# Altium schematic sheet Quadruped.SchDoc(Quadruped)
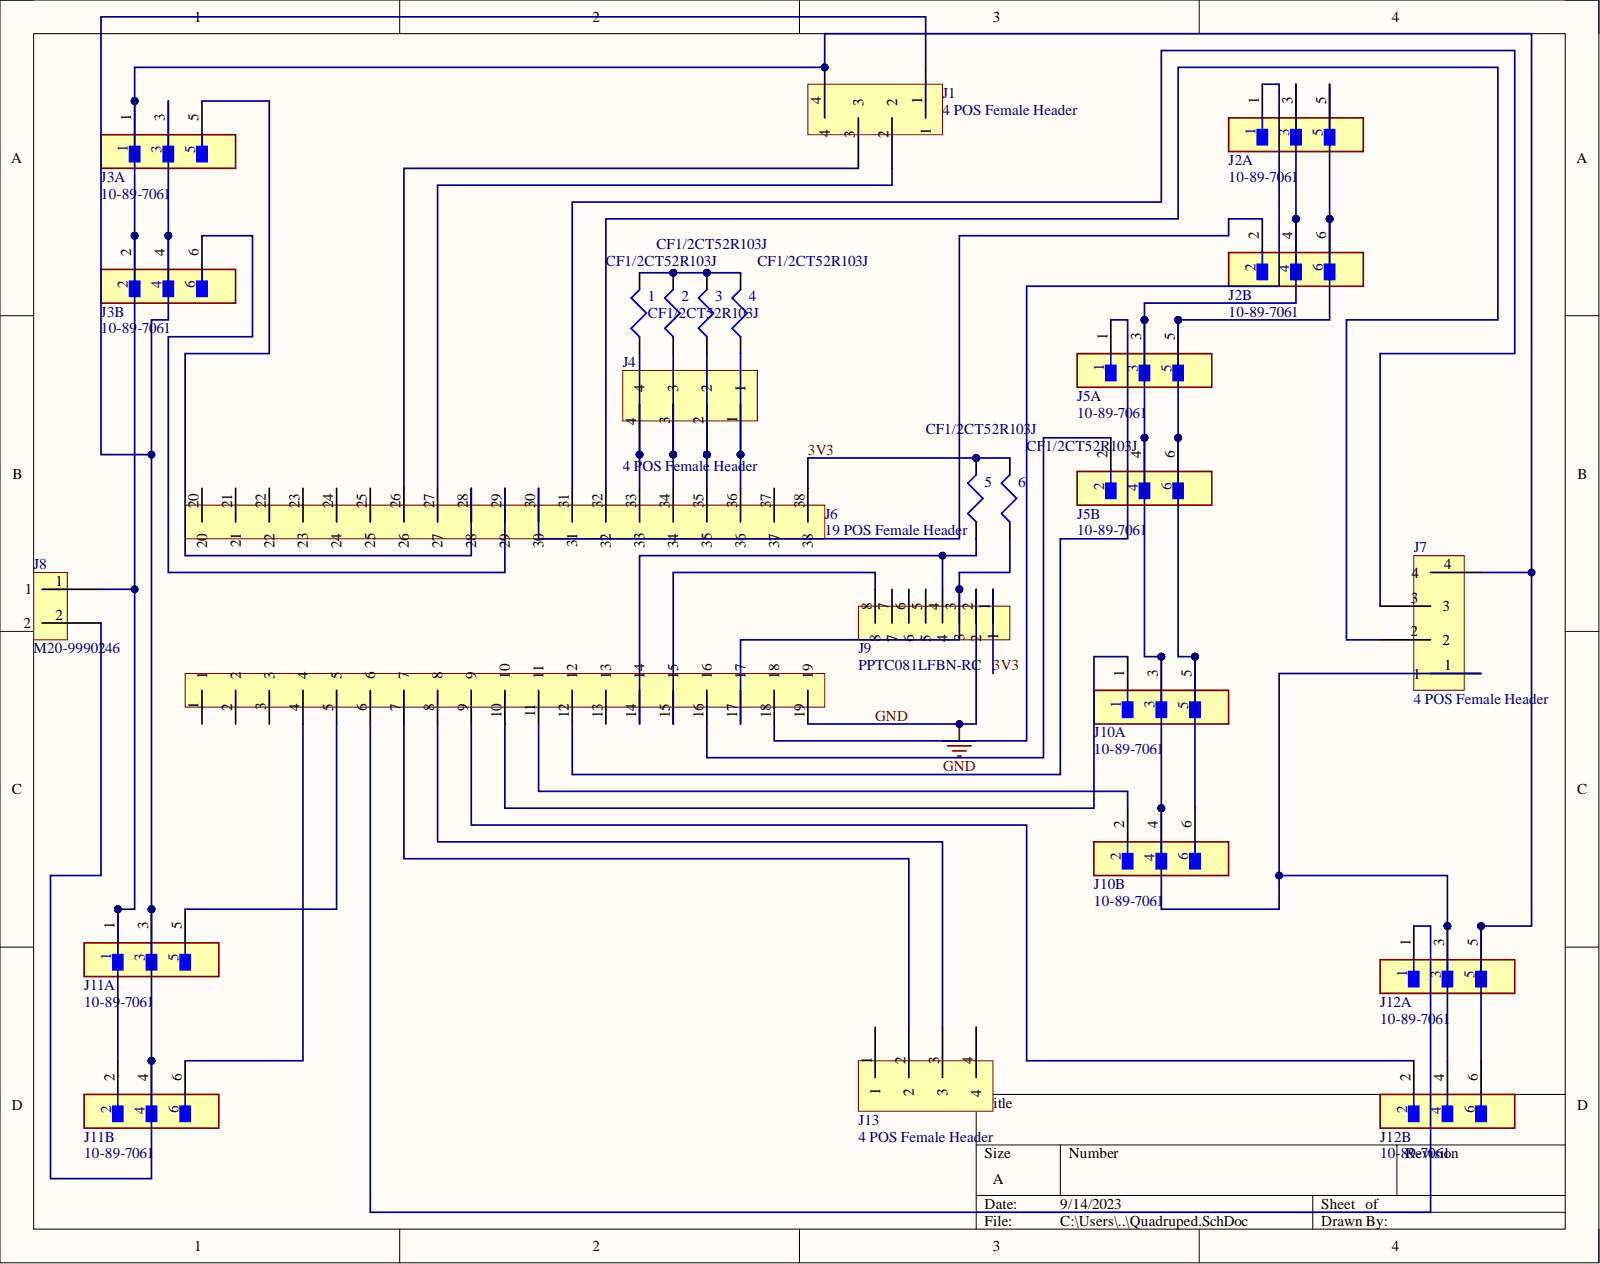
[diagram p0: Quadruped.SchDoc]

## Parts
1  CF1/2CT52R103J  [10K 1/2W]
2  CF1/2CT52R103J  [10K 1/2W]
3  CF1/2CT52R103J  [10K 1/2W]
4  CF1/2CT52R103J  [10K 1/2W]
5  CF1/2CT52R103J  [10K 1/2W]
6  CF1/2CT52R103J  [10K 1/2W]
J1  4 POS Female Header  [SULLINS_PPTC041LFBN-RC]
J2  10-89-7061  [3x2_MALE_HEADER]  (parts A,B)
J3  10-89-7061  [3x2_MALE_HEADER]  (parts A,B)
J4  4 POS Female Header  [SULLINS_PPTC041LFBN-RC]
J5  10-89-7061  [3x2_MALE_HEADER]  (parts A,B)
J6  19 POS Female Header  [ESP_32]
J7  4 POS Female Header  [SULLINS_PPTC041LFBN-RC]
J8  M20-9990246  [2x1_MALE_HEADER]
J9  PPTC081LFBN-RC  [8POS_FEMALE_HEADER]
J10  10-89-7061  [3x2_MALE_HEADER]  (parts A,B)
J11  10-89-7061  [3x2_MALE_HEADER]  (parts A,B)
J12  10-89-7061  [3x2_MALE_HEADER]  (parts A,B)
J13  4 POS Female Header  [SULLINS_PPTC041LFBN-RC]

## Nets
3V3: 5.2 6.2 J6.38 J9.1
GND: J6.19 J9.2
Net1_1: 1.1 J4.4 J6.33
Net1_2: 1.2 2.2 3.2 4.2
Net2_1: 2.1 J4.3 J6.34
Net3_1: 3.1 J4.2 J6.35
Net4_1: 4.1 J4.1 J6.36
Net5_1: 5.1 J6.14 J9.4
Net6_1: 6.1 J6.17 J9.3
NetJ1_1: J1.1 J3.3 J3.4 J8.2 J11.3 J11.4
NetJ1_2: J1.2 J6.27
NetJ1_3: J1.3 J6.26
NetJ1_4: J1.4 J3.1 J3.2 J7.4 J8.1 J11.1 J11.2 J12.5 J12.6
NetJ2_1: J2.1 J6.18
NetJ2_2: J2.2 J6.30
NetJ2_3: J2.3 J2.4 J5.3 J5.4 J7.1 J10.3 J10.4 J12.3 J12.4
NetJ2_5: J2.5 J2.6 J5.5 J5.6 J10.5 J10.6
NetJ3_5: J3.5 J6.28
NetJ3_6: J3.6 J6.29
NetJ5_1: J5.1 J6.12
NetJ5_2: J5.2 J6.16
NetJ6_4: J6.4 J11.6
NetJ6_5: J6.5 J11.5
NetJ6_6: J6.6 J12.1
NetJ6_7: J6.7 J13.2
NetJ6_8: J6.8 J13.3
NetJ6_9: J6.9 J12.2
NetJ6_10: J6.10 J10.1
NetJ6_11: J6.11 J10.2
NetJ6_15: J6.15 J9.8
NetJ6_31: J6.31 J7.3
NetJ6_32: J6.32 J7.2

Not connected: J6 1 2 3 13 20 21 22 23 24 25 37; J9 5 6 7; J13 1 4

## Library data of the parts
1-6 = CF1/2CT52R103J: Res, 10K, 0.5W, Axial, Carbon Film Rohs Compliant: Yes |Koa CF1/2CT52R103J; Manufacturer: KOA Speer; Power Rating: 500mW; Temperature Coefficient: 350ppm/°C; Tolerance: 5%; Value: 10kR; Voltage Rating: 400V; Case/Package: Conformal; Category: Through-Hole Resistors; Component Kind: Standard; ComponentLink1Description: https://datasheet.ciiva.com/5478/cf-5478522.pdf?src-supplier=Mouser; ComponentLink1URL: https://datasheet.ciiva.com/5478/cf-5478522.pdf?src-supplier=Mouser; ComponentLink2Description: https://datasheet.octopart.com/CF1-2CT52R103J-KOA-Speer-datasheet-149521760.pdf?src-supplier=Farnell; ComponentLink2URL: https://datasheet.octopart.com/CF1-2CT52R103J-KOA-Speer-datasheet-149521760.pdf?src-supplier=Farnell; ComponentLink3Description: https://datasheet.octopart.com/CF1/2CT52R103J-KOA-Speer-datasheet-160006.pdf?src-supplier=Mouser; ComponentLink3URL: https://datasheet.octopart.com/CF1/2CT52R103J-KOA-Speer-datasheet-160006.pdf?src-supplier=Mouser; Construction: Cylindrical; Length: 8.5mm; Manufacturer Part Number: CF1/2CT52R103J; Max Operating Temperature: 155°C; Military Standard: Not; Min Operating Temperature: -55°C; Mounting Technology: Through Hole; Package Quantity: 4000; Packaging: Tape and Reel; Pricing: 1=0.12, 10=0.104, 25=0.072, 50=0.0558, 100=0.0399, 250=0.03112, 500=0.02474, 1000=0.01755 (USD); RoHS Compliant: Yes; Series: CF; Stock: 26977; Supplier: Digi-Key; Supplier Part Number: 2019-CF1/2CT52R103JCT-ND; Termination: Axial; Library Name: Try1.SchLib
J1 J7 = PPPC041LFBN-RC_OPPOSITE: CONN HDR 4POS 0.1 GOLD PCB; Manufacturer: Sullins; Category: Connectors; Component Kind: Standard; ComponentLink1Description: https://media.digikey.com/pdf/Data%20Sheets/Sullins%20PDFs/Female_Headers.100_DS.pdf?src-supplier=Digi-Key; ComponentLink1URL: https://media.digikey.com/pdf/Data%20Sheets/Sullins%20PDFs/Female_Headers.100_DS.pdf?src-supplier=Digi-Key; ComponentLink2Description: http://www.sullinscorp.com/catalogs/78_Page80-81_HEADER_.100_2.54mm_FEMALE.pdf?src-supplier=Future+Electronics; ComponentLink2URL: http://www.sullinscorp.com/catalogs/78_Page80-81_HEADER_.100_2.54mm_FEMALE.pdf?src-supplier=Future+Electronics; ComponentLink3Description: https://datasheet.octopart.com/PPPC041LFBN-RC-Sullins-datasheet-41308905.pdf?src-supplier=Future+Electronics; ComponentLink3URL: https://datasheet.octopart.com/PPPC041LFBN-RC-Sullins-datasheet-41308905.pdf?src-supplier=Future+Electronics; Manufacturer Part Number: PPPC041LFBN-RC; Pricing: 1=0.47, 10=0.411, 100=0.3391, 500=0.29484, 1000=0.24324, 5000=0.21376, 10000=0.2106 (USD); RoHS: Yes; Stock: 27520; Supplier: Digi-Key; Supplier Part Number: S7037-ND; Library Name: Try1.SchLib
J2 J3 J5 J10-J12 = 2654a11fb13586ecb194516b1c997bc: CONN HEADER VERT 6POS 2.54MM; Connector Type: Male Pin; Contact Resistance: 15mR; Current Rating: 3A; Gender: Male; Glow Wire Compliant: Non-compliant; Number of Circuits: 6; Row Spacing: 0.1inch; Voltage Rating: 250V; Color: Black; Component Kind: Standard; Contact Gender: Male; Contact Material: Brass; Contact Mating Length: 0.24inch; Contact Plating: Tin; Contact Type: Male Pin; Depth: 5.08mm; ELV: Compliant; Flammability Rating: UL94 V-0; Halogen Free: Low Halogen; Housing Color: Black; Housing Material: Thermoplastic; Lead Free: Lead Free; Material: Copper Alloy; Mount: Through Hole; Number of Contacts: 6; Number of Positions: 6; Number of Rows: 2; Orientation: Straight; Package Quantity: 1; Packaging: Bulk; Pitch: 2.54mm; Radiation Hardening: No; RoHS Compliant: Yes; Series: C-Grid® 70280; Termination: Solder; Voltage Rating (DC): 250V; Library Name: Try1.SchLib
J4 J13 = PPPC041LFBN-RC: CONN HDR 4POS 0.1 GOLD PCB; Manufacturer: Sullins; Category: Connectors; Component Kind: Standard; ComponentLink1Description: https://media.digikey.com/pdf/Data%20Sheets/Sullins%20PDFs/Female_Headers.100_DS.pdf?src-supplier=Digi-Key; ComponentLink1URL: https://media.digikey.com/pdf/Data%20Sheets/Sullins%20PDFs/Female_Headers.100_DS.pdf?src-supplier=Digi-Key; ComponentLink2Description: http://www.sullinscorp.com/catalogs/78_Page80-81_HEADER_.100_2.54mm_FEMALE.pdf?src-supplier=Future+Electronics; ComponentLink2URL: http://www.sullinscorp.com/catalogs/78_Page80-81_HEADER_.100_2.54mm_FEMALE.pdf?src-supplier=Future+Electronics; ComponentLink3Description: https://datasheet.octopart.com/PPPC041LFBN-RC-Sullins-datasheet-41308905.pdf?src-supplier=Future+Electronics; ComponentLink3URL: https://datasheet.octopart.com/PPPC041LFBN-RC-Sullins-datasheet-41308905.pdf?src-supplier=Future+Electronics; Manufacturer Part Number: PPPC041LFBN-RC; Pricing: 1=0.47, 10=0.411, 100=0.3391, 500=0.29484, 1000=0.24324, 5000=0.21376, 10000=0.2106 (USD); RoHS: Yes; Stock: 27520; Supplier: Digi-Key; Supplier Part Number: S7037-ND; Library Name: Try1.SchLib
J6 = PPTC191LFBN-RC: CONN HDR 19POS 0.1 TIN PCB; Manufacturer: Sullins; Number of Positions: 19; Voltage Rating: 250V; Category: Connectors; Component Kind: Standard; ComponentLink1Description: https://s3.amazonaws.com/catalogspreads-pdf/PAGE114-115%20.100%20FEMALE%20HDR.pdf?src-supplier=Digi-Key; ComponentLink1URL: https://s3.amazonaws.com/catalogspreads-pdf/PAGE114-115%20.100%20FEMALE%20HDR.pdf?src-supplier=Digi-Key; Connector Type: Header; Contact Finish - Mating: TIN; Contact Material: Bronze; Contact Shape: Square; Contact Type: Female Socket; Fastening Type: Pull; Flammability Rating: UL94 V-0; Height: 8.5mm; Housing Material: Plastic; Insulation Color: Black; Insulation Material: Polyester; Lead Free: Lead Free; Length: 48.8mm; Manufacturer Part Number: PPTC191LFBN-RC; Max Operating Temperature: 105°C; Min Operating Temperature: -40°C; Mounting Technology: Through Hole; Number of Rows: 1; Packaging: Tray; Pricing: 1=1.18, 10=0.997, 80=0.89488, 440=0.73218, 1000=0.65083, 5000=0.5811 (USD); RoHS: Yes; RoHS Compliant: Yes; Series: -; Stock: 11638; Supplier: Digi-Key; Supplier Part Number: S7017-ND; Termination: Solder; Width: 2.5mm; Library Name: Try1.SchLib
J8 = M20-9990246: 2.54mm (0.1") Pitch SIL Vertical PC Tail Pin Header, 6.1mm mating pin height, tin, 2 contacts; Manufacturer: Harwin; Category: Headers and Wire Housings; Component Kind: Standard; ComponentLink1Description: https://datasheet.ciiva.com/8046/315376-8046930.pdf?src-supplier=Element14; ComponentLink1URL: https://datasheet.ciiva.com/8046/315376-8046930.pdf?src-supplier=Element14; ComponentLink2Description: https://datasheet.ciiva.com/11745/0900766b81034e61-11745755.pdf?src-supplier=Rs; ComponentLink2URL: https://datasheet.ciiva.com/11745/0900766b81034e61-11745755.pdf?src-supplier=Rs; ComponentLink3Description: https://datasheet.ciiva.com/22660/1830818-22660571.pdf?src-supplier=Element14; ComponentLink3URL: https://datasheet.ciiva.com/22660/1830818-22660571.pdf?src-supplier=Element14; ComponentLink4Description: https://datasheet.ciiva.com/6833/m20-999-6833368.pdf?src-supplier=Digi-Key; ComponentLink4URL: https://datasheet.ciiva.com/6833/m20-999-6833368.pdf?src-supplier=Digi-Key; ComponentLink5Description: https://datasheet.ciiva.com/26852/getdatasheetpartid-384170-26852203.pdf?src-supplier=Verical; ComponentLink5URL: https://datasheet.ciiva.com/26852/getdatasheetpartid-384170-26852203.pdf?src-supplier=Verical; Manufacturer Part Number: M20-9990246; RoHS: Yes; Stock: 4090; Supplier: Rs; Supplier Part Number: 7457065; Library Name: Try1.SchLib
J9 = PPTC081LFBN-RC: Female, 8C, Straight, .100"CC; 3.20mm Tail, No Mt. 100u" Tin; PBT, Phos Bronze, 105 C; Manufacturer: Sullins; Category: Connectors; Component Kind: Standard; ComponentLink1Description: https://media.digikey.com/pdf/Data%20Sheets/Sullins%20PDFs/Female_Headers.100_DS.pdf?src-supplier=Digi-Key; ComponentLink1URL: https://media.digikey.com/pdf/Data%20Sheets/Sullins%20PDFs/Female_Headers.100_DS.pdf?src-supplier=Digi-Key; ComponentLink2Description: https://datasheet.octopart.com/PPTC081LFBN-RC-Sullins-datasheet-41308905.pdf?src-supplier=Future+Electronics; ComponentLink2URL: https://datasheet.octopart.com/PPTC081LFBN-RC-Sullins-datasheet-41308905.pdf?src-supplier=Future+Electronics; ComponentLink3Description: http://www.sullinscorp.com/catalogs/78_Page80-81_HEADER_.100_2.54mm_FEMALE.pdf?src-supplier=Future+Electronics; ComponentLink3URL: http://www.sullinscorp.com/catalogs/78_Page80-81_HEADER_.100_2.54mm_FEMALE.pdf?src-supplier=Future+Electronics; Manufacturer Part Number: PPTC081LFBN-RC; Pricing: 1=0.65, 10=0.565, 400=0.42525, 800=0.3645, 1200=0.33413, 5200=0.29363, 10000=0.2925 (USD); RoHS: Yes; Stock: 25448; Supplier: Digi-Key; Supplier Part Number: S7006-ND; Library Name: Try1.SchLib
